# Altium schematic sheet com.SchDoc(com)
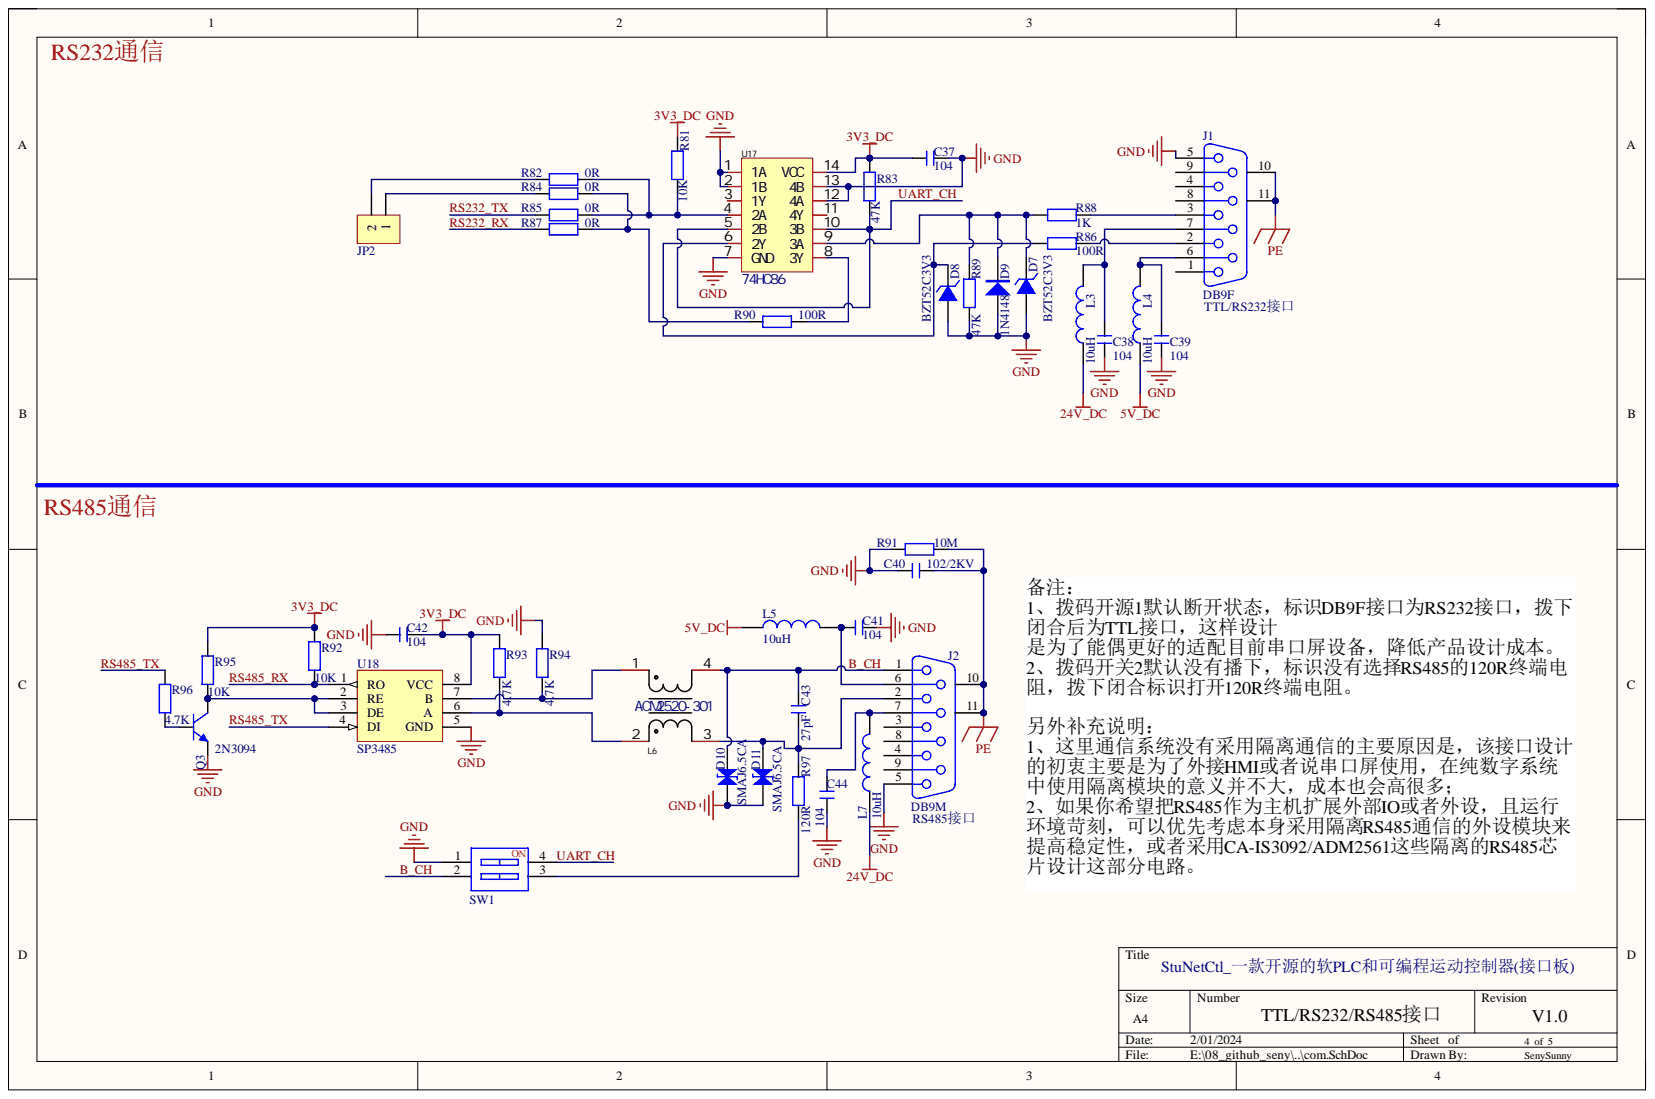
[diagram p0: com.SchDoc]

## Parts
C37  104  [C 0603]
C38  104  [C 0603]
C39  104  [C 0603]
C40  102/2KV  [C 1206]
C41  104  [C 0603]
C42  104  [C 0603]
C43  27pF  [C 0603]
C44  104  [C 0603]
D7  BZT52C3V3  [SOD-323]
D8  BZT52C3V3  [SOD-323]
D9  1N4148  [SOD-323]
D10  SMAJ6.5CA  [SMA(DO214AC)]
D11  SMAJ6.5CA  [SMA(DO214AC)]
J1  DB9F  [DB9F]
J2  DB9M  [DB9M]
JP2  HDR-1X2  [HDR-1X2_M]  (value not drawn)
L3  10uH  [L 1206]
L4  10uH  [L 1206]
L5  10uH  [L 1206]
L6  ACM2520-301  [ACM2520]
L7  10uH  [L 1206]
Q3  2N3094  [SOT23-3N]
R81  10K  [R 0603]
R82  0R  [R 0603]
R83  47K  [R 0603]
R84  0R  [R 0603]
R85  0R  [R 0603]
R86  100R  [R 0603]
R87  0R  [R 0603]
R88  1K  [R 0603]
R89  47K  [R 0603]
R90  100R  [R 0603]
R91  10M  [R 1206]
R92  10K  [R 0603]
R93  4.7K  [R 0603]
R94  4.7K  [R 0603]
R95  10K  [R 0603]
R96  4.7K  [R 0603]
R97  120R  [R 0603]
SW1  CSW-2P  [CSW-TH_2P]  (value not drawn)
U17  74HC86  [SOP14_L]
U18  SP3485  [SOP8_L]

## Nets
3V3_DC: C37.2 C42.1 R81.2 R83.2 R92.2 R93.1 R95.2 U17.14(VCC) U18.8(VCC)
5V_DC: L4.1 L5.1
24V_DC: L3.1 L7.1
GND: C37.1 C38.1 C39.1 C40.2 C41.1 C42.2 C44.1 D7.1 D8.1 D9.1 D10.1 D11.1 J1.5 J2.5 Q3.2 R89.1 R91.2 R94.1 SW1.1 U17.1(1A) U17.2(1B) U17.7(GND) U17.12(4A) U17.13(4B) U18.5(GND)
PE: C40.1 J1.10 J1.11 J2.10 J2.11 R91.1
B_CH: C43.1 D10.2 J2.1 L6.4 SW1.2
RS232_RX: R87.2
RS232_TX: R85.2
RS485_RX: R92.1 U18.1(RO)
RS485_TX: R96.1 U18.4(DI)
UART_CH: R83.1 SW1.4 U17.5(2B) U17.10(3B)
NetC38_2: C38.2 J1.7 L3.2
NetC39_2: C39.2 J1.6 L4.2
NetC41_2: C41.2 J2.6 L5.2
NetC43_2: C43.2 D11.2 J2.2 L6.3 R97.1
NetC44_2: C44.2 J2.7 L7.2
NetD7_2: D7.2 D9.2 R88.1 R89.2 U17.9(3A)
NetD8_2: D8.2 R86.1 U17.6(2Y)
NetJ1_2: J1.2 R86.2
NetJ1_3: J1.3 R88.2
NetJP2_1: JP2.1 R84.2
NetJP2_2: JP2.2 R82.2
NetL6_1: L6.1 R94.2 U18.7(B)
NetL6_2: L6.2 R93.2 U18.6(A)
NetQ3_1: Q3.1 R96.2
NetQ3_3: Q3.3 R95.1 U18.2(RE) U18.3(DE)
NetR81_1: R81.1 R82.1 R85.1 U17.4(2A)
NetR84_1: R84.1 R87.1 R90.2
NetR90_1: R90.1 U17.8(3Y)
NetR97_2: R97.2 SW1.3

Not connected: J1 1 4 8 9; J2 3 4 8 9; U17 3(1Y) 11(4Y)

## Library data of the parts
C37-C44 = C: 无极性贴片电容; 版权归属: 源创客; BOM名称: 无极性贴片电容; Component Kind: Standard; 学习资源: www.ooocke.com; Library Name: zblib_v1.IntLib
D7 D8 = 1N4733: 5.1V/1W稳压管; 版权归属: 源创客; BOM名称: 5.1V/1W稳压管; Component Kind: Standard; 学习资源: www.ooocke.com; Library Name: zblib_v1.IntLib
D9 = 1N4148: 高速开关二极管; 版权归属: 源创客; BOM名称: 高速开关二极管; Component Kind: Standard; 学习资源: www.ooocke.com; Library Name: zblib_v1.IntLib
D10 D11 = DR: 瞬态电压抑制二极管; 版权归属: 源创客; BOM名称: 瞬态电压抑制二极管; Component Kind: Standard; 学习资源: www.ooocke.com; Library Name: zblib_v1.IntLib
J1 = DB9F: DB9接插件，母头，孔; Component Kind: Standard; Library Name: zblib_v1.IntLib
J2 = DB9M: DB9接插件，公头，针; Component Kind: Standard; Library Name: zblib_v1.IntLib
JP2 = Header 2: 2P接插件; 版权归属: 源创客; BOM名称: 2P接插件; Component Kind: Standard; 学习资源: www.ooocke.com; Library Name: zblib_v1.IntLib
L3-L5 L7 = L: 小功率贴片电感; 版权归属: 源创客; BOM名称: 小功率贴片电感; Component Kind: Standard; 学习资源: www.ooocke.com; Library Name: zblib_v1.IntLib
L6 = ACM2520-301-2P-T002: 两路 2520共模滤波器; Manufacturer: TDK; Add into BOM: yes; Current Rating: 400mA; DC Resistance (DCR): 350mΩ; Impedance @ Frequency: 300Ω@100MHz; Number of Lines: 2; Voltage Rating - DC: 20V; Component Kind: Standard; Convert to PCB: yes; Datasheet: https://atta.szlcsc.com/upload/public/pdf/source/20160918/1474166840139.pdf; Device: ACM2520-301-2P-T002; JLCPCB Part Class: Extended Part; LCSC Part Name: 300Ω@100MHz 400mA; Manufacturer Part: ACM2520-301-2P-T002; Name: ACM2520-301-2P-T002; Operating Temperature: -40℃~+85℃; Supplier: LCSC; Supplier Footprint: 2520; Supplier Part: C76577; Symbol: ACM2520-301-2P-T002; Library Name: zblib_v1.IntLib
Q3 = 8050-SMD: 高频放大-NPN型; 属性: 40V 1.5A 1W 100MHZ; 版权归属: 源创客; BOM名称: 高频放大-NPN型; Component Kind: Standard; 学习资源: www.ooocke.com; Library Name: zblib_v1.IntLib
R81-R97 = R: 贴片电阻; 版权归属: 源创客; BOM名称: 贴片电阻; Component Kind: Standard; 学习资源: www.ooocke.com; Library Name: zblib_v1.IntLib
SW1 = CSW-2P: 2路编码开关; 版权归属: 源创客; BOM名称: 2路编码开关; Component Kind: Standard; 学习资源: www.ooocke.com; Library Name: zblib_v1.IntLib
U17 = 74HC86: 4通道异或门; Manufacturer: Nexperia(安世); Add into BOM: yes; Device: 74HC86PW,118; High Level Range (VIH): 1.5V~4.2V; Logic Gate Type: 异或门; Low Level Range (VIL): 500mV~1.8V; Number of Channels: 4; Propagation Delay Time: 20ns@6V,50pF; Quiescent Current (Max): 2uA; Sink Current: 5.2mA; Supply Voltage: 2V~6V; Component Kind: Standard; Convert to PCB: yes; Datasheet: https://atta.szlcsc.com/upload/public/pdf/source/20170919/C113209_1505787064027976698.pdf; JLCPCB Part Class: Extended Part; LCSC Part Name: 74HC86PW,118; Manufacturer Part: 74HC86PW,118; Name: 74HC86PW,118; Operating Temperature: -40℃~+125℃; Series: 74HC; Source Current: 5.2mA; Supplier: LCSC; Supplier Footprint: TSSOP-14; Supplier Part: C113209; Symbol: 74HC86PW,118; Library Name: zblib_v1.IntLib
U18 = SP3485: RS485总线收发芯片; 版权归属: 源创客; BOM名称: RS485总线收发芯片; Component Kind: Standard; 学习资源: www.ooocke.com; Library Name: zblib_v1.IntLib
